# Altium schematic sheet fpga_misc.SchDoc
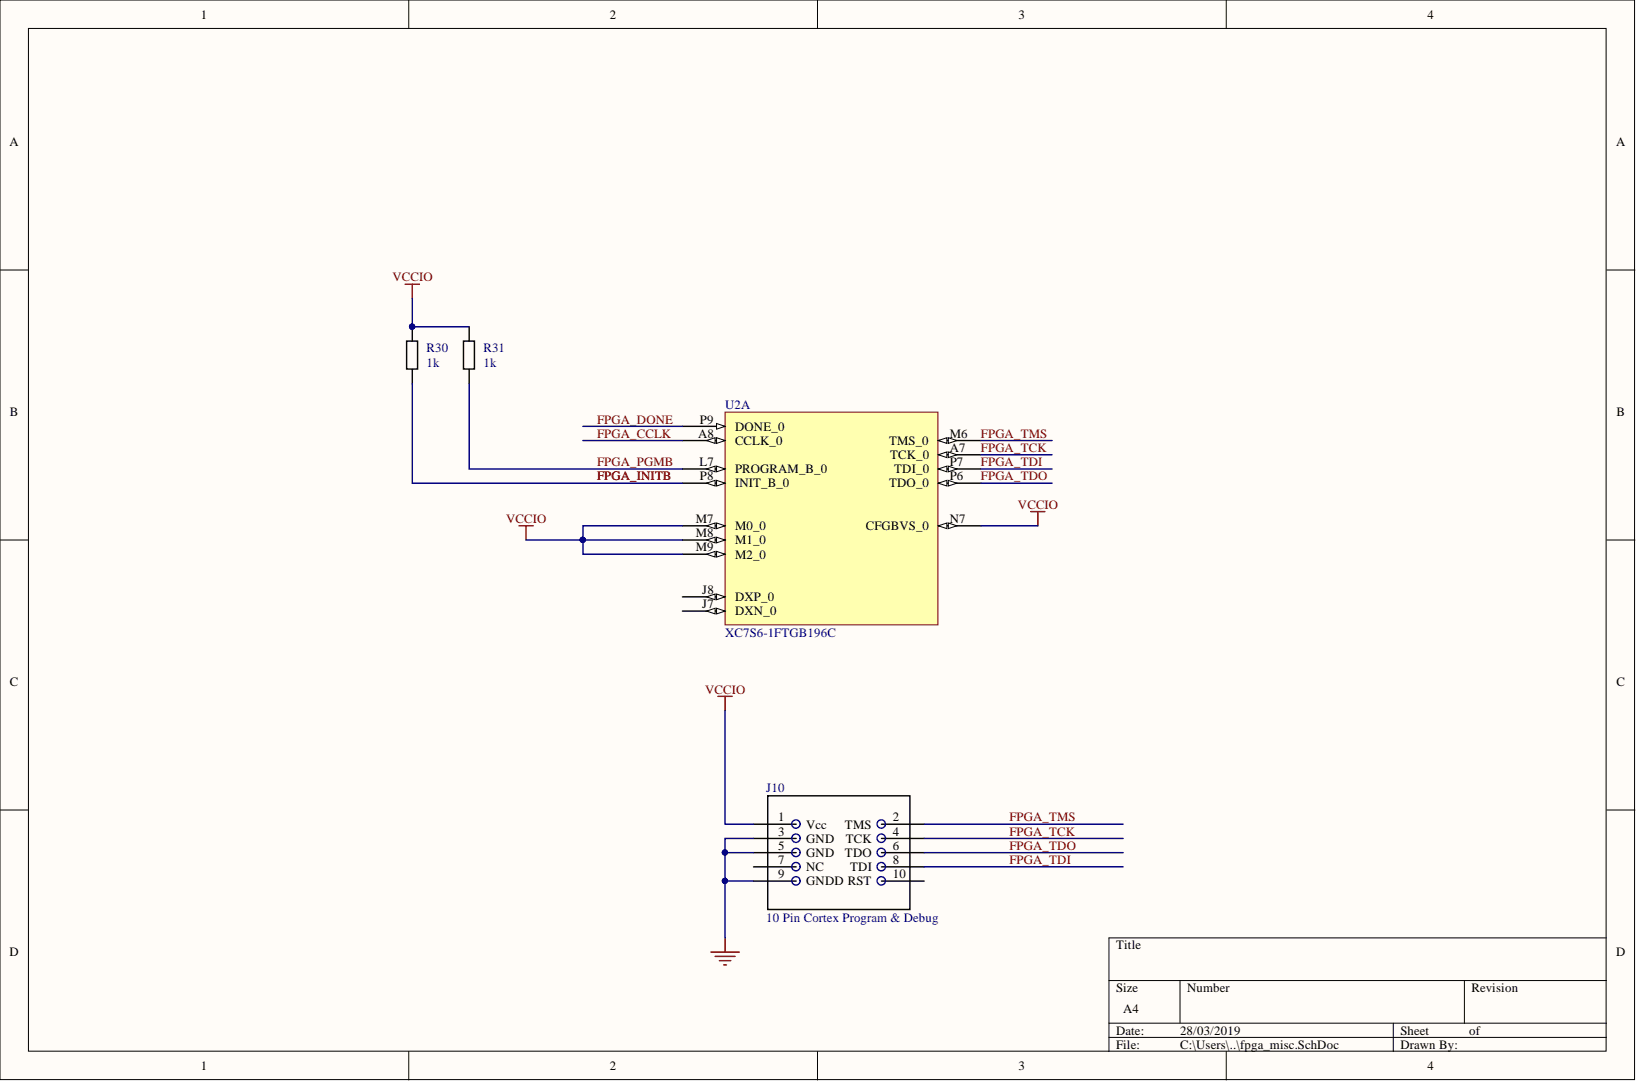
[diagram p0: fpga_misc.SchDoc]

## Parts
J10  10 Pin Cortex Program & Debug  [0.5mm_SMD_Header_5x2]
R30  1k  [0603]
R31  1k  [0603]
U2  XC7S6-1FTGB196C  [BGA_FTGB196]  (part A)

## Nets
GND: J10.3(GND) J10.5(GND) J10.9(GNDD)
VCCIO: J10.1(Vcc) R30.1 R31.1 U2.M7(M0_0) U2.M8(M1_0) U2.M9(M2_0) U2.N7(CFGBVS_0)
FPGA_CCLK: U2.A8(CCLK_0)
FPGA_DONE: U2.P9(DONE_0)
FPGA_INITB: R30.2 U2.P8(INIT_B_0)
FPGA_PGMB: R31.2 U2.L7(PROGRAM_B_0)
FPGA_TCK: J10.4(TCK) U2.A7(TCK_0)
FPGA_TDI: J10.8(TDI) U2.P7(TDI_0)
FPGA_TDO: J10.6(TDO) U2.P6(TDO_0)
FPGA_TMS: J10.2(TMS) U2.M6(TMS_0)

Not connected: J10 7(NC) 10(RST); U2 J7(DXN_0) J8(DXP_0)

## Library data of the parts
J10 = Cortex_Debug_Header_10Pin: 10 Pin Cortex Program & Debug 0.5mm pitch; Manufacture 1: Samtec Inc; Manufacture Part Number 1: FTSH-105-01-L-DV-007-K; Component Group: Connector; Database Table Name: main; PP Material Stack: 0; Component Kind: Standard; Component Type: Standard; Library Name: NewAE_AltiumLib.DBLib; Mounting Technology: SMT; Part Number: Cortex_Debug_Header_10Pin; Pin Count: 10; PP Rotation: 0; RoHS: Yes; Supplier 1: Digi-Key; Supplier Part Number 1: SAM9438-ND
R30 R31 = RCHIP-1K-0603: 1k res, 0603, 1%, 1/10W; Manufacture 1: Yageo; Manufacture Part Number 1: RC0603FR-071KL; Case-Metric: 1608; Component Group: Resistor; Database Table Name: main; Rated Power: 0.1W; Rated Voltage: 75VDC; Case-EIA: 0603; Component Kind: Standard; Component Type: Standard; Library Name: NewAE_AltiumLib.DBLib; Mounting Technology: SMT; Part Number: RCHIP-1K-0603; Pin Count: 2; Supplier 1: Digi-Key; Supplier Part Number 1: 311-1.00KHRCT-ND; Temperature Range: -55C to 155C; Tolerance: 1%; Value: 1k
U2 = XC7S6-1FTGB196C: Xilinx Spartan 7 FPGA, 196FTGB; Component Group: Digital IC; Database Table Name: main; PP Material Stack: 0; Component Kind: Standard; Component Type: Standard; Library Name: NewAE_AltiumLib.DBLib; Manufacture 1: Xilinx; Manufacture Part Number 1: XC7S6-1FTGB196C; Part Number: XC7S6-1FTGB196C; Pin Count: 196; PP Rotation: 0; Supplier 1: Digi-Key; Supplier Part Number 1: 122-2240-ND
